# Altium schematic sheet CN032-1 Industry EtherCAT Servo Controller_Encoder.SchDoc
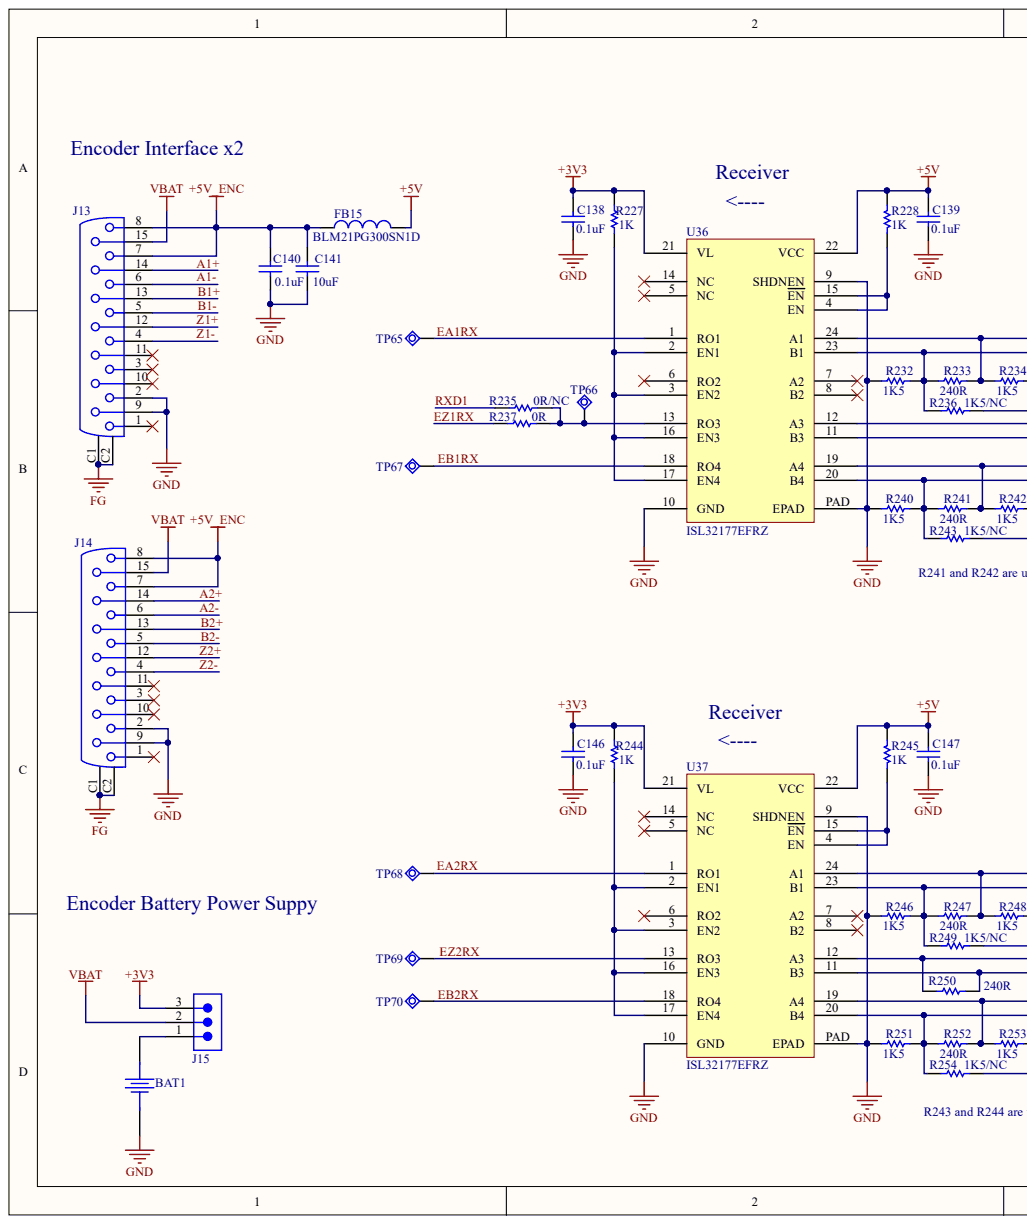
[diagram p0: CN032-1 Industry EtherCAT Servo Controller_Encoder.SchDoc, left]
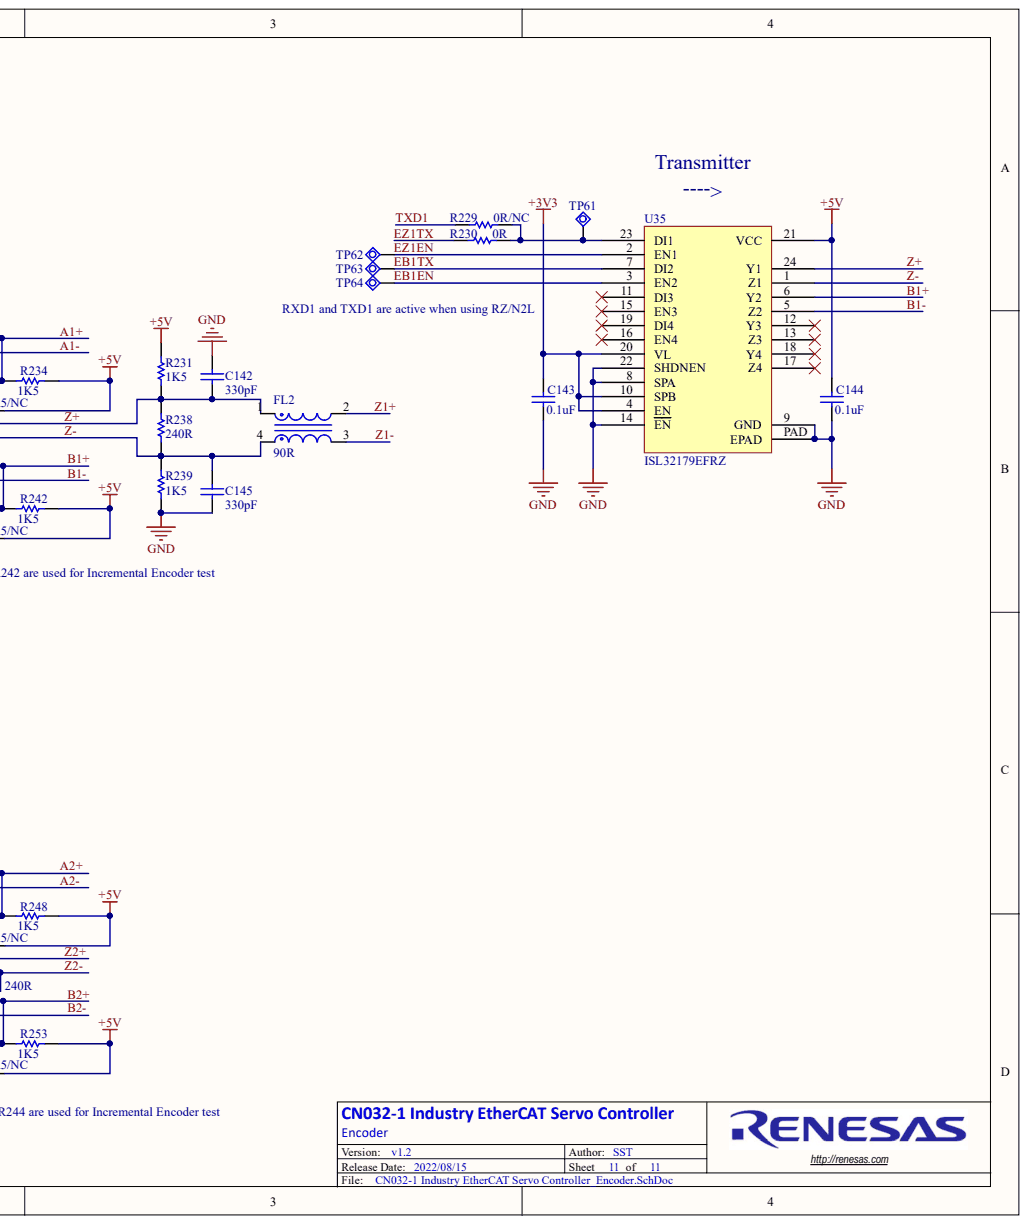
[diagram p1: CN032-1 Industry EtherCAT Servo Controller_Encoder.SchDoc, right]

## Parts
BAT1  CONN HEADER 2.5MM  [XH2]  (value not drawn)
C138  0.1uF  [CAPC0402-01]
C139  0.1uF  [CAPC0402-01]
C140  0.1uF  [CAPC0402-01]
C141  10uF  [CAPC0603-01]
C142  330pF  [CAPC0402-01]
C143  0.1uF  [CAPC0402-01]
C144  0.1uF  [CAPC0402-01]
C145  330pF  [CAPC0402-01]
C146  0.1uF  [CAPC0402-01]
C147  0.1uF  [CAPC0402-01]
FB15  BLM21PG300SN1D  [FB_0805]
FL2  90R  [CKS4B20X12X - duplicate]
J13  D-SUB  [D15S13A4GV00LF_NEW]  (value not drawn)
J14  D-SUB  [D15S13A4GV00LF_NEW]  (value not drawn)
J15  2.54mm 1x3  [FCI_6800-x03]  (value not drawn)
R227  1K  [RESC0402-01]
R228  1K  [RESC0402-01]
R229  0R/NC  [RESC0402-01]
R230  0R  [RESC0402-01]
R231  Resistance: 1K5  [RESC0402-01]  (hidden comment: 1.5K)
R232  Resistance: 1K5  [RESC0402-01]  (hidden comment: 1.5K)
R233  240R  [RESC0402-01]
R234  Resistance: 1K5  [RESC0402-01]  (hidden comment: 1.5K)
R235  0R/NC  [RESC0402-01]
R236  Resistance: 1K5/NC  [RESC0402-01]  (hidden comment: 1.5K/NC)
R237  0R  [RESC0402-01]
R238  240R  [RESC0402-01]
R239  Resistance: 1K5  [RESC0402-01]  (hidden comment: 1.5K)
R240  Resistance: 1K5  [RESC0402-01]  (hidden comment: 1.5K)
R241  240R  [RESC0402-01]
R242  Resistance: 1K5  [RESC0402-01]  (hidden comment: 1.5K)
R243  Resistance: 1K5/NC  [RESC0402-01]  (hidden comment: 1.5K/NC)
R244  1K  [RESC0402-01]
R245  1K  [RESC0402-01]
R246  Resistance: 1K5  [RESC0402-01]  (hidden comment: 1.5K)
R247  240R  [RESC0402-01]
R248  Resistance: 1K5  [RESC0402-01]  (hidden comment: 1.5K)
R249  Resistance: 1K5/NC  [RESC0402-01]  (hidden comment: 1.5K/NC)
R250  240R  [RESC0402-01]
R251  Resistance: 1K5  [RESC0402-01]  (hidden comment: 1.5K)
R252  240R  [RESC0402-01]
R253  Resistance: 1K5  [RESC0402-01]  (hidden comment: 1.5K)
R254  Resistance: 1K5/NC  [RESC0402-01]  (hidden comment: 1.5K/NC)
TP61  Test Point  [TEST POINT]  (value not drawn)
TP62  Test Point  [TEST POINT]  (value not drawn)
TP63  Test Point  [TEST POINT]  (value not drawn)
TP64  Test Point  [TEST POINT]  (value not drawn)
TP65  Test Point  [TEST POINT]  (value not drawn)
TP66  Test Point  [TEST POINT]  (value not drawn)
TP67  Test Point  [TEST POINT]  (value not drawn)
TP68  Test Point  [TEST POINT]  (value not drawn)
TP69  Test Point  [TEST POINT]  (value not drawn)
TP70  Test Point  [TEST POINT]  (value not drawn)
U35  ISL32179EFRZ  [QFN-24]
U36  ISL32177EFRZ  [QFN-24]
U37  ISL32177EFRZ  [QFN-24]

## Nets
+3V3: C138.1 C143.1 C146.1 J15.3 R227.2 R244.2 U35.4(EN) U35.10(SPB) U35.20(VL) U36.21(VL) U37.21(VL)
+5V: C139.1 C144.1 C147.1 FB15.2 R228.2 R231.2 R234.2 R236.2 R242.2 R243.2 R245.2 R248.2 R249.2 R253.2 R254.2 U35.21(VCC) U36.22(VCC) U37.22(VCC)
+5V_ENC: C140.2 C141.2 FB15.1 J13.7 J13.8 J14.7 J14.8
FG: J13.C1 J13.C2 J14.C1 J14.C2
GND: BAT1.2 C138.2 C139.2 C140.1 C141.1 C142.2 C143.2 C144.2 C145.1 C146.2 C147.2 J13.2 J13.9 J14.2 J14.9 R232.1 R239.1 R240.1 R246.1 R251.1 U35.8(SPA) U35.9(GND) U35.14(EN) U35.22(SHDNEN) U35.PAD(EPAD) U36.9(SHDNEN) U36.10(GND) U36.PAD(EPAD) U37.9(SHDNEN) U37.10(GND) U37.PAD(EPAD)
VBAT: J13.15 J14.15 J15.2
A1+: J13.14 R233.2 R234.1 U36.24(A1)
A1-: J13.6 R232.2 R233.1 R236.1 U36.23(B1)
A2+: J14.14 R247.2 R248.1 U37.24(A1)
A2-: J14.6 R246.2 R247.1 R249.1 U37.23(B1)
B1+: J13.13 R241.2 R242.1 U35.6(Y2) U36.19(A4)
B1-: J13.5 R240.2 R241.1 R243.1 U35.5(Z2) U36.20(B4)
B2+: J14.13 R252.2 R253.1 U37.19(A4)
B2-: J14.5 R251.2 R252.1 R254.1 U37.20(B4)
EA1RX: TP65.1 U36.1(RO1)
EA2RX: TP68.1 U37.1(RO1)
EB1EN: TP64.1 U35.3(EN2)
EB1RX: TP67.1 U36.18(RO4)
EB1TX: TP63.1 U35.7(DI2)
EB2RX: TP70.1 U37.18(RO4)
EZ1EN: TP62.1 U35.2(EN1)
EZ1RX: R237.1
EZ1TX: R230.1
EZ2RX: TP69.1 U37.13(RO3)
RXD1: R235.1
TXD1: R229.1
Z1+: FL2.2 J13.12
Z1-: FL2.3 J13.4
Z2+: J14.12 R250.1 U37.12(A3)
Z2-: J14.4 R250.2 U37.11(B3)
Z+: C142.1 FL2.1 R231.1 R238.2 U35.24(Y1) U36.12(A3)
Z-: C145.2 FL2.4 R238.1 R239.2 U35.1(Z1) U36.11(B3)
NetBAT1_1: BAT1.1 J15.1
NetR227_1: R227.1 U36.2(EN1) U36.3(EN2) U36.16(EN3) U36.17(EN4)
NetR228_1: R228.1 U36.4(EN) U36.15(EN)
NetR229_2: R229.2 R230.2 TP61.1 U35.23(DI1)
NetR235_2: R235.2 R237.2 TP66.1 U36.13(RO3)
NetR244_1: R244.1 U37.2(EN1) U37.3(EN2) U37.16(EN3) U37.17(EN4)
NetR245_1: R245.1 U37.4(EN) U37.15(EN)

Not connected: J13 1 3 10 11; J14 1 3 10 11; U35 11(DI3) 12(Y3) 13(Z3) 15(EN3) 16(EN4) 17(Z4) 18(Y4) 19(DI4); U36 5(NC) 6(RO2) 7(A2) 8(B2) 14(NC); U37 5(NC) 6(RO2) 7(A2) 8(B2) 14(NC)

## Library data of the parts
BAT1 = CON-Battery AAA 2.5mm 1x2: CONN HEADER VERT 2POS 2.5MM; Manufacturer: JST Sales America Inc.; Mfg Part Number: B2B-XH-A(LF)(SN); Component Kind: Standard; Library Name: Synergy.SchLib
C138-C140 C143 C144 C146 C147 = CAP-0402-0.1uF-YAGEO: Ceramic Chip Capacitor 0402 0.1uF 16V; Manufacturer: YAGEO; Mfg Part Number: CC0402KPX7R7BB104; Dialectric: X7R; Tolerance: 10%; Capacitance: 0.1uF; Component Kind: Standard; Package: 0402; Voltage: 16V; Library Name: Synergy.SchLib
C141 = CAP-0603-10uF: Ceramic Chip Capacitor 0603 10uF 10V; Manufacturer: YAGEO; Mfg Part Number: CC0603MRX5R6BB106; Dialectric: X5R; Tolerance: 20%; Capacitance: 10uF; Component Kind: Standard; Package: 0603; Voltage: 10V; Library Name: Synergy.IntLib
C142 C145 = CAP-0402-330pF: Ceramic Chip Capacitor 0402 330pF 50V; Manufacturer: YAGEO; Mfg Part Number: CC0402KRX7R9BB331; Dialectric: X7R; Tolerance: 10%; Capacitance: 330pF; Component Kind: Standard; Package: 0402; Voltage: 50V; Library Name: Synergy.IntLib
FB15 = FB-BLM21PG300SN1D: FERRITE BEAD 30 OHM 0805 1LN; Manufacturer: Murata; Current: 4A; Resistance: 14mΩ; Component Kind: Standard; Mfg Part Number: BLM21PG300SN1D; Package: 0805; Library Name: Synergy.SchLib
FL2 = IND-SMD0805-LC: Common Choke,90ohm@100MHz/20°C,±25%,330mA,0.35ohm,SMD0805; Manufacturer: Murata; Mfg Part Number: DLW21SN900SQ2B; Component Kind: Standard; Part Type: Common Mode Inductor; Rating: 330mA; Value: 90R; Library Name: Synergy.IntLib
J13 J14 = CON-D-SUB: CONN D-SUB RCPT 15POS R/A SLDR; Manufacturer: FCI; Mfg Part Number: D15S13A4GV00LF; Component Kind: Standard; Library Name: Synergy.SchLib
J15 = CON-2.54mm 1x3: Header 0.1" pitch 3pos 1x3; Manufacturer: FCI; Mfg Part Number: 77311-818-03LF; Positions: 3; Component Kind: Standard; Pitch: 0.1"; Library Name: Synergy.SchLib
R227 R228 R244 R245 = RES-0402-1K: Chip Resistor Thick Film 0402 1K 1% 1/16W; Manufacturer: YAGEO; Mfg Part Number: RC0402FR-071KL; Component Kind: Standard; Package: 0402; Power: 1/16W; Resistance: 1K; Tolerance: 1%; Library Name: Synergy.SchLib
R229 R230 R235 R237 = RES-0402-0R: Chip Resistor Thick Film 0402 0R 1% 1/16W; Manufacturer: YAGEO; Mfg Part Number: RC0402JR-070RL; Component Kind: Standard; Package: 0402; Power: 1/16W; Tolerance: 1%; Library Name: Synergy.SchLib
  R229 R235: Resistance: 0R/NC
  R230 R237: Resistance: 0R
R231 R232 R234 R236 R239 R240 R242 R243 R246 R248 R249 R251 R253 R254 = RES-0402-1.5K: Chip Resistor Thick Film 0402 1K5 1% 1/16W; Manufacturer: YAGEO; Mfg Part Number: RC0402FR-071K5L; Component Kind: Standard; Package: 0402; Power: 1/16W; Tolerance: 1%; Library Name: Synergy.SchLib
R233 R238 R241 R247 R250 R252 = RES-0402-240R: Chip Resistor Thick Film 0402 240R 1% 1/16W; Manufacturer: YAGEO; Mfg Part Number: RC0402FR-07240RL; Component Kind: Standard; Package: 0402; Power: 1/16W; Resistance: 240R; Tolerance: 1%; Library Name: Synergy.SchLib
TP61-TP70 = CON-Test Point: Component Kind: Standard; Description: Test Point; Library Name: Synergy.SchLib
U35 = ICN-ISL32179EFRZ: IC DRIVER 4/0 24QFN; Manufacturer: Renesas; Component Kind: Standard; Display Name: ISL32179EFRZ; Mfg Part Number: ISL32179EFRZ; Package: 24-QFN（4x4）; Library Name: Synergy.SchLib
U36 U37 = ICN-ISL32177EFRZ: IC RECEIVER 0/4 24QFN; Manufacturer: Renesas; Component Kind: Standard; Display Name: ISL32177EFRZ; Mfg Part Number: ISL32177EFRZ; Package: 24-QFN（4x4）; Library Name: Synergy.SchLib
